# Altium schematic sheet TubePreAmp.SchDoc
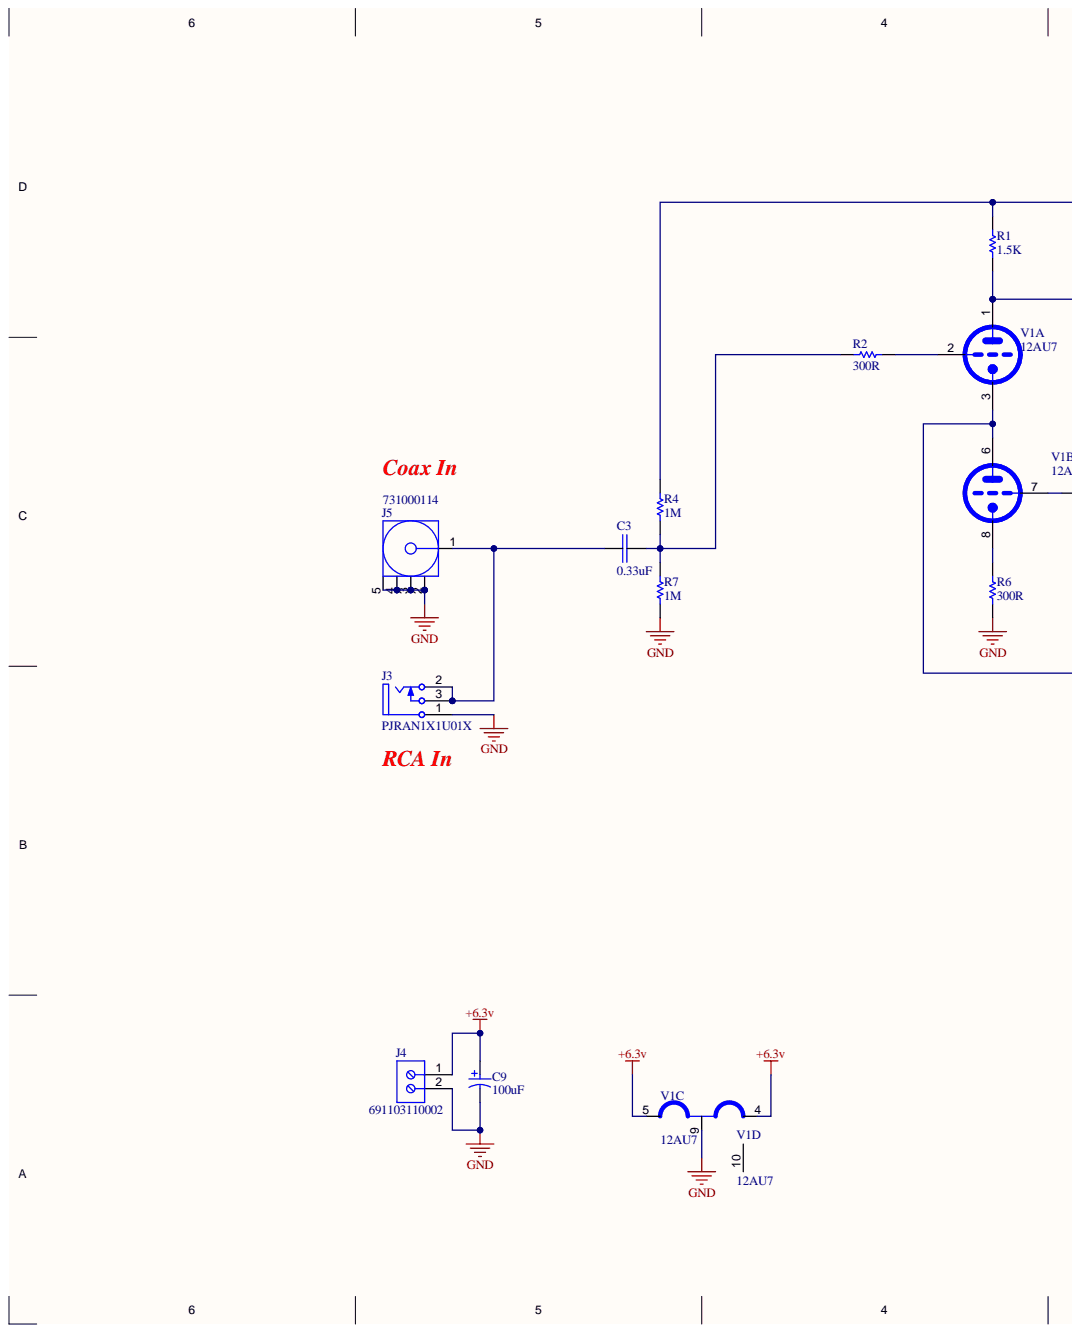
[diagram p0: TubePreAmp.SchDoc, left]
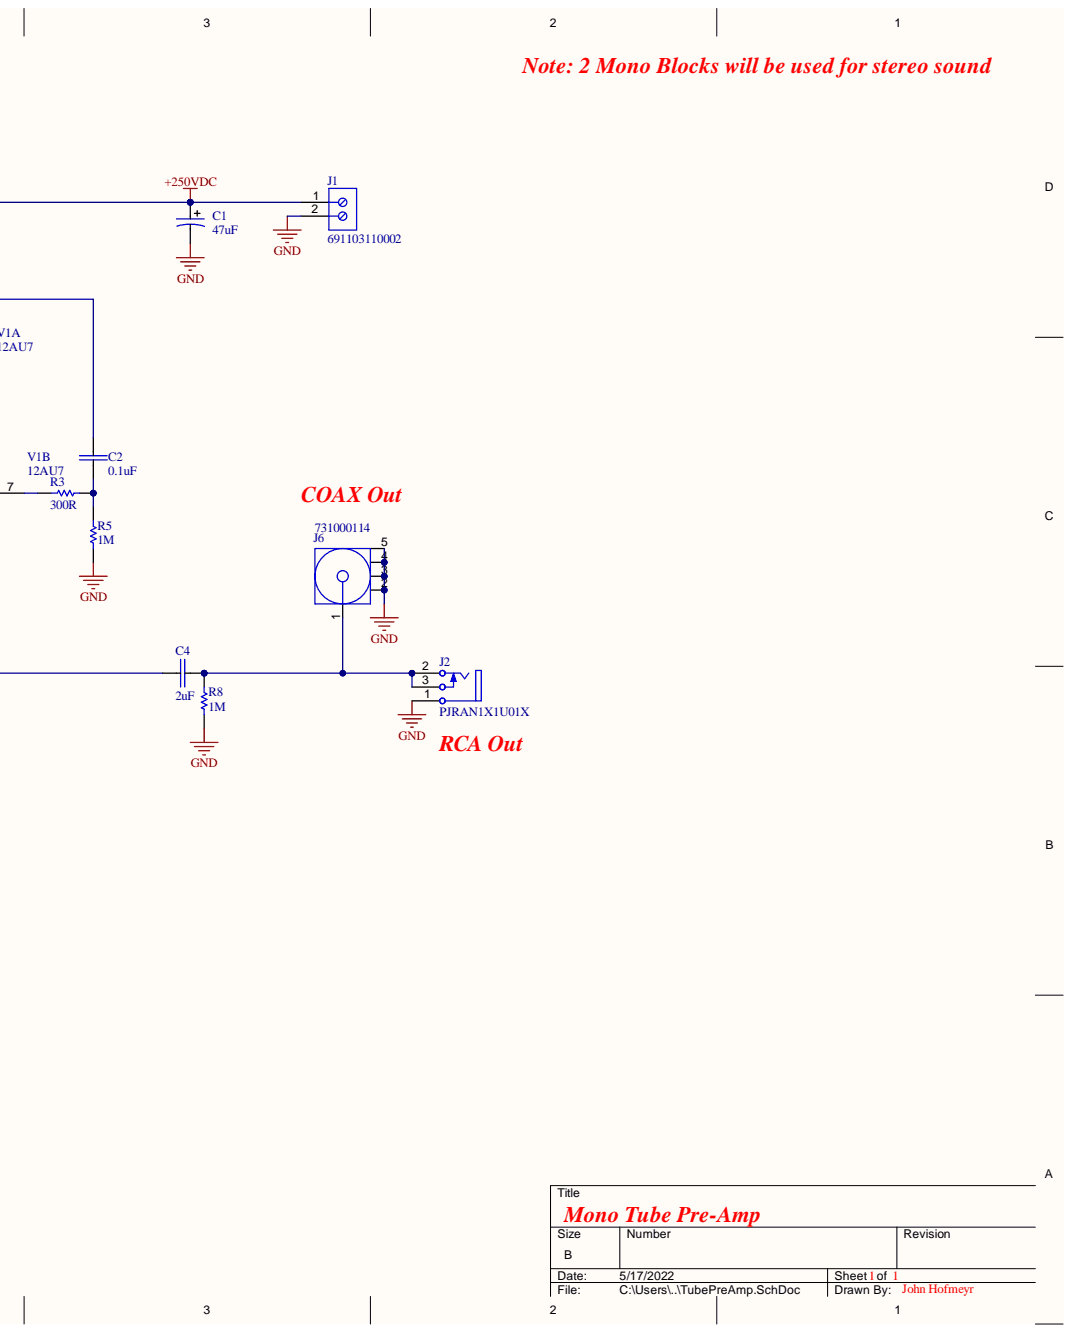
[diagram p1: TubePreAmp.SchDoc, right]

## Parts
C1  47uF  [C-4745]
C2  0.1uF  [C-940C-01]
C3  0.33uF  [C-940C-33]
C4  2uF  [C-940C-2]
C9  100uF  [CAPPR55-250-1120X630X1220]
J1  691103110002  [691103110002]
J2  PJRAN1X1U01X  [SWIT-PJRAN1X1U01X_V]
J3  PJRAN1X1U01X  [SWIT-PJRAN1X1U01X_V]
J4  691103110002  [691103110002]
J5  731000114  [MOLX-731000114_V]
J6  731000114  [MOLX-731000114_V]
R1  1.5K  [RESA90-1450X520]
R2  300R  [RESA60-630X240]
R3  300R  [RESA60-630X240]
R4  1M  [RESA60-630X240]
R5  1M  [RESA60-630X240]
R6  300R  [RESA90-1450X520]
R7  1M  [RESA60-630X240]
R8  1M  [RESA60-630X240]
V1  12AU7  [CMC-9PT]  (parts A,B,C,D)

## Nets
+6.3v: C9.1 J4.1 V1.4 V1.5
+250VDC: C1.1 J1.1 R1.2 R4.2
GND: C1.2 C9.2 J1.2 J2.1 J3.1 J4.2 J5.2 J5.3 J5.4 J5.5 J6.2 J6.3 J6.4 J6.5 R5.1 R6.1 R7.1 R8.2 V1.9
NetC2_1: C2.1 R1.1 V1.1
NetC2_2: C2.2 R3.2 R5.2
NetC3_1: C3.1 J3.2 J3.3 J5.1
NetC3_2: C3.2 R2.1 R4.1 R7.2
NetC4_1: C4.1 V1.3 V1.6
NetC4_2: C4.2 J2.2 J2.3 J6.1 R8.1
NetR2_2: R2.2 V1.2
NetR3_1: R3.1 V1.7
NetR6_2: R6.2 V1.8

Not connected: V1 10

## Library data of the parts
C1 = UVK2W470MHD: Component Kind: Standard; Library Name: Capacitor.SchLib; Supplier 1: Digi-Key; Supplier Part Number 1: 493-12437-ND
C2 = 940C6P1K-F: Component Kind: Standard; ComponentLink1Description: Digikey; ComponentLink1URL: https://www.digikey.com/en/products/detail/cornell-dubilier-electronics-cde/940C6P33K-F/809430; Library Name: Capacitor.SchLib; Supplier 1: Digi-Key; Supplier Part Number 1: 338-2586-ND
C3 = 940C6P33K-F: Component Kind: Standard; ComponentLink1Description: Digikey; ComponentLink1URL: https://www.digikey.com/en/products/detail/cornell-dubilier-electronics-cde/940C6P33K-F/809430; Library Name: Capacitor.SchLib; Supplier 1: Digi-Key; Supplier Part Number 1: 338-1137-ND
C4 = 940C6W2K-F: Component Kind: Standard; ComponentLink1Description: Digikey; ComponentLink1URL: https://www.digikey.com/en/products/detail/cornell-dubilier-electronics-cde/940C6P33K-F/809430; Library Name: Capacitor.SchLib; Supplier 1: Digi-Key; Supplier Part Number 1: 338-1140-ND
C9 = CMP-1665-00015-4: Aluminum Electrolytic Capacitor (Radial Lead Type), 100 uF, 35 V, -40 to 85 degC, 2-Pin THD, RoHS, Bulk; Manufacturer: Panasonic; Voltage Rating: 35 V; Component Kind: Standard; ComponentLink1Description: Manufacturer URL; ComponentLink1URL: http://www.panasonic.com/; ComponentLink2Description: Datasheet; ComponentLink2URL: http://industrial.panasonic.com/cdbs/www-data/pdf/RDF0000/ABA0000C1047.pdf; DatasheetVersion: 03/2016; Height: 11.2 mm; Lead Pitch: 2.5 mm; Length: 11.2 mm; Library Name: Altium Content Vault; Max Operating Temperature: 85 degC; Min Operating Temperature: -40 degC; Mount: Through Hole; Mounting Technology: Through Hole; Package Quantity: 200; PackageDescription: 2-Pin Through Hole Device, Dia 6.3 mm, Body Length 11.2 mm; PackageReference: Radial; Packaging: Bulk; Pins: 2; REACH SVHC: No SVHC; RoHSCompliant: true
J1 J4 = CMP-1502-03254-1: Series 1031 - 3.50 mm Horizontal Entry Modular with Pressure Clamp WR-TBL, 2 pin; Manufacturer: Wurth Elektronik; Pin Number: 2; Pitch: 3.50mm; Component Kind: Standard; Datasheet URL: http://katalog.we-online.de/em/datasheet/6911031100xx.pdf; Library Name: Altium Content Vault; Manufacturer URL: http://www.we-online.de; Mounting Technology: THT; Operating Temperature: -40to105degC; Package Reference: 691103110002; Packaging: Box; Part Number: 691103110002; Publisher: WurthElektronik
J2 J3 = CMP-1772-00003-1: Female Phono Jack, 3 Position, Height 13 mm, Tail Length 4 mm, RoHS, Bulk; Capacitance: 100 nF; Case\Package: Radial; Tolerance: 10%; Type (Capacitors): X7R; Voltage Rating: 50 V; Component Kind: Standard; ComponentLink1Description: Manufacturer URL; ComponentLink1URL: http://www.switchcraft.com/; ComponentLink2Description: Datasheet; ComponentLink2URL: http://www.conxall.com/Drawings/pjran1x1u__x_series_cd.pdf; DatasheetVersion: Rev. A, 01/2006; Height: 3.18 mm; Lead Pitch: 2.54 mm; Length: 6.6 mm; Library Name: Altium Content Vault; Max Operating Temperature: 125 degC; Min Operating Temperature: -55 degC; Mount: Through Hole; Package Quantity: 500; PackageDescription: Female Phono Jack, 3 Position, Height 13 mm, Tail Length 4 mm; PackageReference: PJRAN1X1U01X; Packaging: Bulk; Pins: 2; Radiation Hardening: No; REACH SVHC: No SVHC; RoHSCompliant: true; Voltage Rating (DC): 50 V; Width: 5.08 mm
J5 J6 = CMP-2000-05487-1: SMA Right-Angle PCB Jack Receptacle, Brass Body, Gold Plated, 50 Ohm, 5-Pin THD, RoHS, Tray; Manufacturer: Molex; Component Kind: Standard; Datasheet URL: http://www.molex.com/webdocs/datasheets/pdf/en-us/0731000114_RF_COAX_CONNECTORS.pdf; Datasheet Version: 09/2016; Library Name: Altium Content Vault; Manufacturer URL: http://www.molex.com/; Mounting Technology: ThroughHole; Package Description: 5-Pin Through Hole Device, Body 14.8 x 6.35 mm, Pitch 2.54 mm; Package Reference: 731000114; Package URL: http://www.molex.com/pdm_docs/sd/731000114_sd.pdf
R1 R6 = CMP-1678-00001-6: Axial Resistor, 25 mOhm, +/- 1%, 3 W, -55 to 275 degC, 2-Pin THD, RoHS, Bulk; Manufacturer: Ohmite; Voltage Rating: 100 mV; Component Kind: Standard; ComponentLink1Description: Manufacturer URL; ComponentLink1URL: http://www.ohmite.com/; ComponentLink2Description: Datasheet; ComponentLink2URL: http://www.ohmite.com/cat/res_10.pdf; DatasheetVersion: 06/2015; Length: 14.5 mm; Library Name: Altium Content Vault; Max Operating Temperature: 275 degC; Min Operating Temperature: -55 degC; Mount: Through Hole; Mounting Technology: Through Hole; Package Quantity: 10; PackageDescription: 2-Pin Axial Lead, Dia 5.2 mm, Body Length 14.5 mm; PackageReference: Axial Resistor; Packaging: Bulk; Pins: 2; REACH SVHC: Unknown; RoHSCompliant: true
R2-R5 R7 R8 = CMP-1659-00063-1: Axial Resistor, 47.5 KOhm, +/- 1%, 0.25 W, -55 to 155 degC, 2-Pin THD, RoHS, Bulk; Case\Package: 1008; Current Rating: 1.3 A; Shielding: Shielded; Tolerance: 20%; Case Code (Metric): 2520; Component Kind: Standard; ComponentLink1Description: Manufacturer URL; ComponentLink1URL: http://www.yageo.com/; ComponentLink2Description: Datasheet; ComponentLink2URL: http://www.yageo.com/documents/recent/Yageo%20LR_MFR_2013.pdf; DatasheetVersion: Apr-13; Height: 1.2 mm; Length: 2.5 mm; Library Name: Altium Content Vault; Max Operating Temperature: 125 degC; Min Operating Temperature: -55 degC; Mount: Surface Mount; Package Quantity: 3000; PackageDescription: 2-Pin Axial Lead, Dia 2.4 mm, Body Length 6.3 mm; PackageReference: MFR-25; Packaging: Tape and Reel; Radiation Hardening: No; RoHSCompliant: true; Width: 2 mm
V1 = 12AU7: MF: {'manufacturer': u'Teonex'}; Availability: Unavailable; Component Kind: Standard; Library Name: 12AU7.SchLib; MP: 12AU7; Package: None; Price: None
